# Altium schematic sheet heater_control.SchDoc
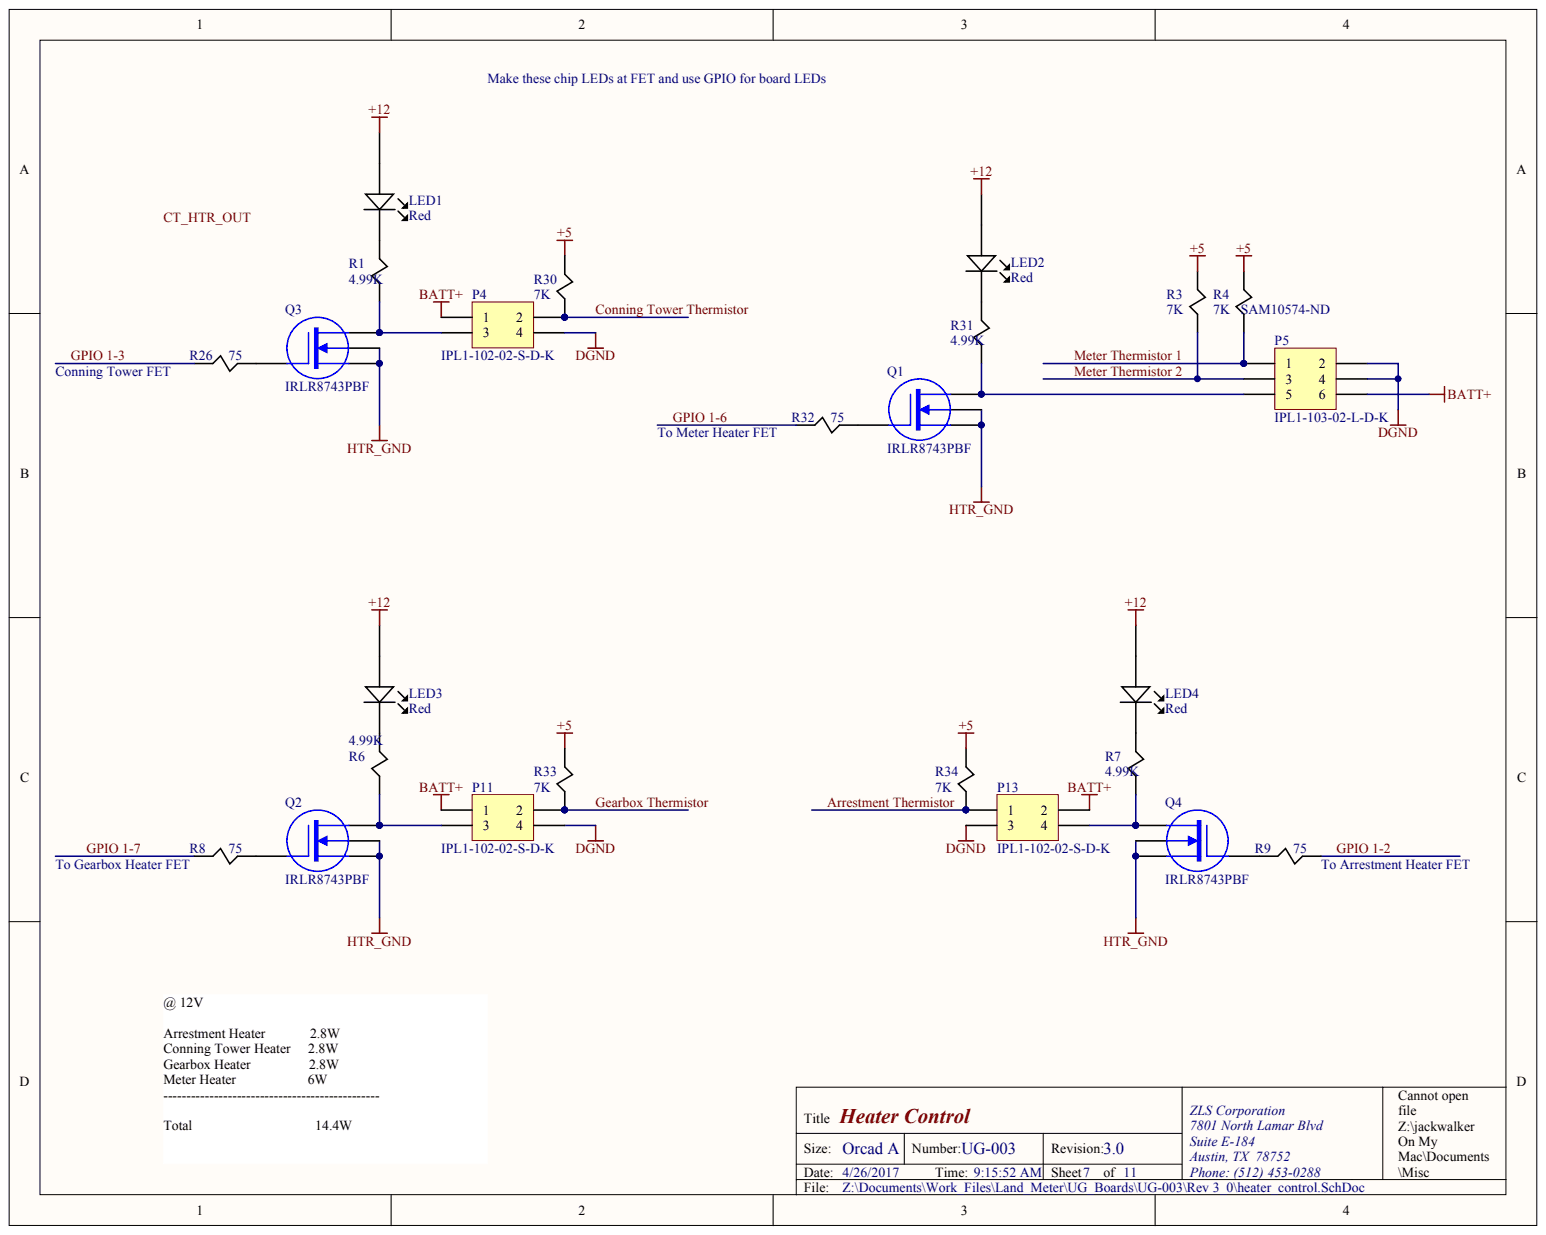
[diagram p0: heater_control.SchDoc]

## Parts
LED1  Red
LED2  Red
LED3  Red
LED4  Red
P4  IPL1-102-02-S-D-K
P5  IPL1-103-02-L-D-K
P11  IPL1-102-02-S-D-K
P13  IPL1-102-02-S-D-K
Q1  IRLR8743PBF
Q2  IRLR8743PBF
Q3  IRLR8743PBF
Q4  IRLR8743PBF
R1  4.99K
R3  7K
R4  7K
R6  4.99K
R7  4.99K
R8  75
R9  75
R26  75
R30  7K
R31  4.99K
R32  75
R33  7K
R34  7K

## Nets
+5: R3.2 R4.2 R30.2 R33.2 R34.2
+12: LED1.A LED2.A LED3.A LED4.A
BATT+: P4.1 P5.6 P11.1 P13.2
DGND: P4.4 P5.2 P5.4 P11.4 P13.3
HTR_GND: Q1.3 Q1.4 Q2.3 Q2.4 Q3.3 Q3.4 Q4.3 Q4.4
ARRESTMENT THERMISTOR: P13.1 R34.1
CONNING TOWER THERMISTOR: P4.2 R30.1
GEARBOX THERMISTOR: P11.2 R33.1
GPIO 1-2: R9.2
GPIO 1-3: R26.1
GPIO 1-6: R32.1
GPIO 1-7: R8.1
METER THERMISTOR 1: P5.1 R4.1
METER THERMISTOR 2: P5.3 R3.1
NetLED1_K: LED1.K R1.2
NetLED2_K: LED2.K R31.2
NetLED3_K: LED3.K R6.2
NetLED4_K: LED4.K R7.2
NetP4_3: P4.3 Q3.2 R1.1
NetP5_5: P5.5 Q1.2 R31.1
NetP11_3: P11.3 Q2.2 R6.1
NetP13_4: P13.4 Q4.2 R7.1
NetQ1_1: Q1.1 R32.2
NetQ2_1: Q2.1 R8.2
NetQ3_1: Q3.1 R26.2
NetQ4_1: Q4.1 R9.1
CT_HTR_OUT:

## Library data of the parts
LED1-LED4 = (no symbol name): Manufacturer 1: Dialight; Manufacturer Part Number 1: 5660406F; Description 1: LED 2MM X 5MM RT ANGLE RED PCMNT; Category 1: Optoelectronics; Wavelength - Peak: 635nm; Configuration: Single; Current: 20mA; Millicandela Rating: 7.4mcd; Viewing Angle: 140°; Lens Type: Diffused, Tinted; Lens Style/Size: Rectangle with Flat Top, 5.00mm x 2.00mm; Voltage Rating: 2V; Supplier 1: Digi-Key; Supplier Part Number 1: 350-1729-ND; RoHS 1: RoHS Compliant; Stock 1: 530; Pricing 1: 1=1.96, 10=1.595, 25=1.2272, 100=1.1044, 250=0.98172, 500=0.83446, 1000=0.6872, 2500=0.65284, 5000=0.63812 (USD); Packaging: Bulk; Part Status: Active; Color: Red; Mounting Type: Through Hole, Right Angle; ComponentLink1URL: http://www.dialight.com/Assets/Brochures_And_Catalogs/Indication/566-xx06.pdf; ComponentLink1Description: http://www.dialight.com/Assets/Brochures_And_Catalogs/Indication/566-xx06.pdf
P4 P11 P13 = (no symbol name): Manufacturer 1: Samtec Inc.; Description 1: CONN WIRE TO BOARD HDR 4 POS; Category 1: Connectors, Interconnects; Connector Type: Header; Pitch - Mating: 0.100" (2.54mm); Style: Board to Cable/Wire; Shrouding: Shrouded - 4 Wall; Number of Positions: 4; Number of Positions Loaded: All; Contact Length - Mating: 0.215" (5.46mm); Material Flammability Rating: UL94 V-0; Voltage Rating: 675VAC, 954VDC; Supplier 1: Digi-Key; Supplier Part Number 1: SAM10572-ND; Manufacturer Part Number 1: IPL1-102-02-S-D-K; RoHS 1: RoHS Compliant; Stock 1: 0; Pricing 1: 1=4.68, 10=4.488, 25=4.114, 50=3.927, 100=3.74, 250=3.27252, 500=3.179, 1000=2.7115, 2500=2.5245 (USD); Packaging: Tube; Part Status: Active; Contact Type: Male Pin; Number of Rows: 2; Row Spacing - Mating: 0.100" (2.54mm); Mounting Type: Surface Mount; Termination: Solder; Fastening Type: Locking Ramp; Contact Length - Post: -; Overall Contact Length: -; Insulation Height: 0.320" (8.13mm); Contact Shape: Square; Contact Finish - Mating: Gold; Contact Finish Thickness - Mating: 30µin (0.76µm); Contact Finish - Post: Tin; Contact Material: Phosphor Bronze; Insulation Material: Liquid Crystal Polymer (LCP); Features: -; Operating Temperature: -55°C ~ 125°C; Ingress Protection: -; Insulation Color: Natural; Current Rating: -; Mated Stacking Heights: -; Contact Finish Thickness - Post: -; Applications: -; ComponentLink1URL: http://suddendocs.samtec.com/prints/ipl1-1xx-xx-xxx-d-xx-x-xx-mkt.pdf; ComponentLink1Description: http://suddendocs.samtec.com/prints/ipl1-1xx-xx-xxx-d-xx-x-xx-mkt.pdf
P5 = (no symbol name): Manufacturer 1: Samtec Inc.; Description 1: CONN SHROUDED PWR HEADER 3POS; Category 1: Connectors, Interconnects; Connector Type: Header; Pitch - Mating: 0.100" (2.54mm); Style: Board to Cable/Wire; Shrouding: Shrouded - 4 Wall; Number of Positions: 6; Number of Positions Loaded: All; Contact Length - Mating: 0.215" (5.46mm); Material Flammability Rating: UL94 V-0; Voltage Rating: 675VAC, 954VDC; Supplier 1: Digi-Key; Supplier Part Number 1: SAM10574-ND; Manufacturer Part Number 1: IPL1-103-02-L-D-K; RoHS 1: RoHS Compliant; Stock 1: 180; Pricing 1: 1=3.13, 10=3, 25=2.75, 50=2.625, 100=2.5, 250=2.18752, 500=2.125, 1000=1.8125, 2500=1.6875 (USD); Packaging: Tube; Part Status: Active; Contact Type: Male Pin; Number of Rows: 2; Row Spacing - Mating: 0.100" (2.54mm); Mounting Type: Surface Mount; Termination: Solder; Fastening Type: Locking Ramp; Contact Length - Post: -; Overall Contact Length: -; Insulation Height: 0.320" (8.13mm); Contact Shape: Square; Contact Finish - Mating: Gold; Contact Finish Thickness - Mating: 10µin (0.25µm); Contact Finish - Post: Tin; Contact Material: Phosphor Bronze; Insulation Material: Liquid Crystal Polymer (LCP); Features: -; Operating Temperature: -55°C ~ 125°C; Ingress Protection: -; Insulation Color: Natural; Current Rating: -; Mated Stacking Heights: -; Contact Finish Thickness - Post: -; Applications: -; ComponentLink1URL: http://suddendocs.samtec.com/prints/ipl1-1xx-xx-xxx-d-xx-x-xx-mkt.pdf; ComponentLink1Description: http://suddendocs.samtec.com/prints/ipl1-1xx-xx-xxx-d-xx-x-xx-mkt.pdf
Q1-Q4 = (no symbol name): Manufacturer 1: Infineon Technologies; Description 1: MOSFET N-CH 30V 160A DPAK; Category 1: Discrete Semiconductor Products; FET Type: N-Channel; Drain to Source Voltage (Vdss): 30V; Current - Continuous Drain (Id) @ 25°C: 160A (Tc); Drive Voltage (Max Rds On,  Min Rds On): 4.5V, 10V; Vgs(th) (Max) @ Id: 2.35V @ 100µA; Gate Charge (Qg) (Max) @ Vgs: 59nC @ 4.5V; Input Capacitance (Ciss) (Max) @ Vds: 4880pF @ 15V; Vgs (Max): ±20V; Power Dissipation (Max): 135W (Tc); Rds On (Max) @ Id, Vgs: 3.1 mOhm @ 25A, 10V; Supplier 1: Digi-Key; Supplier Part Number 1: IRLR8743PBF-ND; Manufacturer Part Number 1: IRLR8743PBF; RoHS 1: RoHS Compliant; Stock 1: 557; Pricing 1: 1=1.29, 10=1.152, 100=0.8984, 500=0.74214, 1000=0.5859 (USD); Packaging: Tube; Part Status: Not For New Designs; Technology: MOSFET (Metal Oxide); FET Feature: -; Operating Temperature: -55°C ~ 175°C (TJ); Mounting Type: Surface Mount; Supplier Device Package: D-Pak; Package / Case: TO-252-3, DPak (2 Leads + Tab), SC-63; ComponentLink1URL: http://www.irf.com/product-info/datasheets/data/irlr8743pbf.pdf; ComponentLink1Description: http://www.irf.com/product-info/datasheets/data/irlr8743pbf.pdf
R1 R6 R7 R31 = (no symbol name): Manufacturer 1: Vishay Dale; Manufacturer Part Number 1: CRCW08054R99FKEA; Description 1: RES SMD 4.99 OHM 1% 1/8W 0805; Category 1: Resistors; Resistance (Ohms): 4.99; Tolerance: ±1%; Power (Watts): 0.125W, 1/8W; Temperature Coefficient: ±100ppm/°C; Supplier 1: Digi-Key; Supplier Part Number 1: 541-4.99CCCT-ND; RoHS 1: RoHS Compliant; Stock 1: 26999; Pricing 1: 1=0.1, 10=0.042, 25=0.0304, 50=0.0232, 100=0.017, 250=0.013, 500=0.0104, 1000=0.00765 (USD); Packaging: Cut Tape (CT); Part Status: Active; Composition: Thick Film; Features: Automotive AEC-Q200; Operating Temperature: -55°C ~ 155°C; Package / Case: 0805 (2012 Metric); Supplier Device Package: 0805; Size / Dimension: 0.079" L x 0.049" W (2.00mm x 1.25mm); Height - Seated (Max): 0.020" (0.50mm); Failure Rate: -; ComponentLink1URL: http://www.vishay.com/docs/20035/dcrcwe3.pdf; ComponentLink1Description: http://www.vishay.com/docs/20035/dcrcwe3.pdf
R3 R4 R30 R33 R34 = (no symbol name): Manufacturer 1: Vishay Dale; Manufacturer Part Number 1: CRCW08056K98FKEA; Description 1: RES SMD 6.98K OHM 1% 1/8W 0805; Category 1: Resistors; Resistance (Ohms): 6.98k; Tolerance: ±1%; Power (Watts): 0.125W, 1/8W; Temperature Coefficient: ±100ppm/°C; Supplier 1: Digi-Key; Supplier Part Number 1: 541-6.98KCCT-ND; RoHS 1: RoHS Compliant; Stock 1: 39695; Pricing 1: 1=0.1, 10=0.042, 25=0.0304, 50=0.0232, 100=0.017, 250=0.013, 500=0.0104, 1000=0.00765 (USD); Packaging: Cut Tape (CT); Part Status: Active; Composition: Thick Film; Features: Automotive AEC-Q200; Operating Temperature: -55°C ~ 155°C; Package / Case: 0805 (2012 Metric); Supplier Device Package: 0805; Size / Dimension: 0.079" L x 0.049" W (2.00mm x 1.25mm); Height - Seated (Max): 0.020" (0.50mm); Failure Rate: -; ComponentLink1URL: http://www.vishay.com/docs/20035/dcrcwe3.pdf; ComponentLink1Description: http://www.vishay.com/docs/20035/dcrcwe3.pdf
R8 R9 R26 R32 = (no symbol name): Manufacturer 1: Vishay Dale; Manufacturer Part Number 1: CRCW080575R0FKEA; Description 1: RES SMD 75 OHM 1% 1/8W 0805; Category 1: Resistors; Tolerance: ±1%; Power (Watts): 0.125W, 1/8W; Temperature Coefficient: ±100ppm/°C; Supplier 1: Digi-Key; Supplier Part Number 1: 541-75.0CCT-ND; RoHS 1: RoHS Compliant; Stock 1: 8423; Pricing 1: 1=0.1, 10=0.042, 25=0.0304, 50=0.0232, 100=0.017, 250=0.013, 500=0.0104, 1000=0.00765 (USD); Packaging: Cut Tape (CT); Part Status: Active; Resistance (Ohms): 75; Composition: Thick Film; Features: Automotive AEC-Q200; Operating Temperature: -55°C ~ 155°C; Package / Case: 0805 (2012 Metric); Supplier Device Package: 0805; Size / Dimension: 0.079" L x 0.049" W (2.00mm x 1.25mm); Height - Seated (Max): 0.020" (0.50mm); Failure Rate: -; ComponentLink1URL: http://www.vishay.com/docs/20035/dcrcwe3.pdf; ComponentLink1Description: http://www.vishay.com/docs/20035/dcrcwe3.pdf
